# Altium schematic sheet MCTA_V2_070322.SchDoc(MCTA_V2_070322)
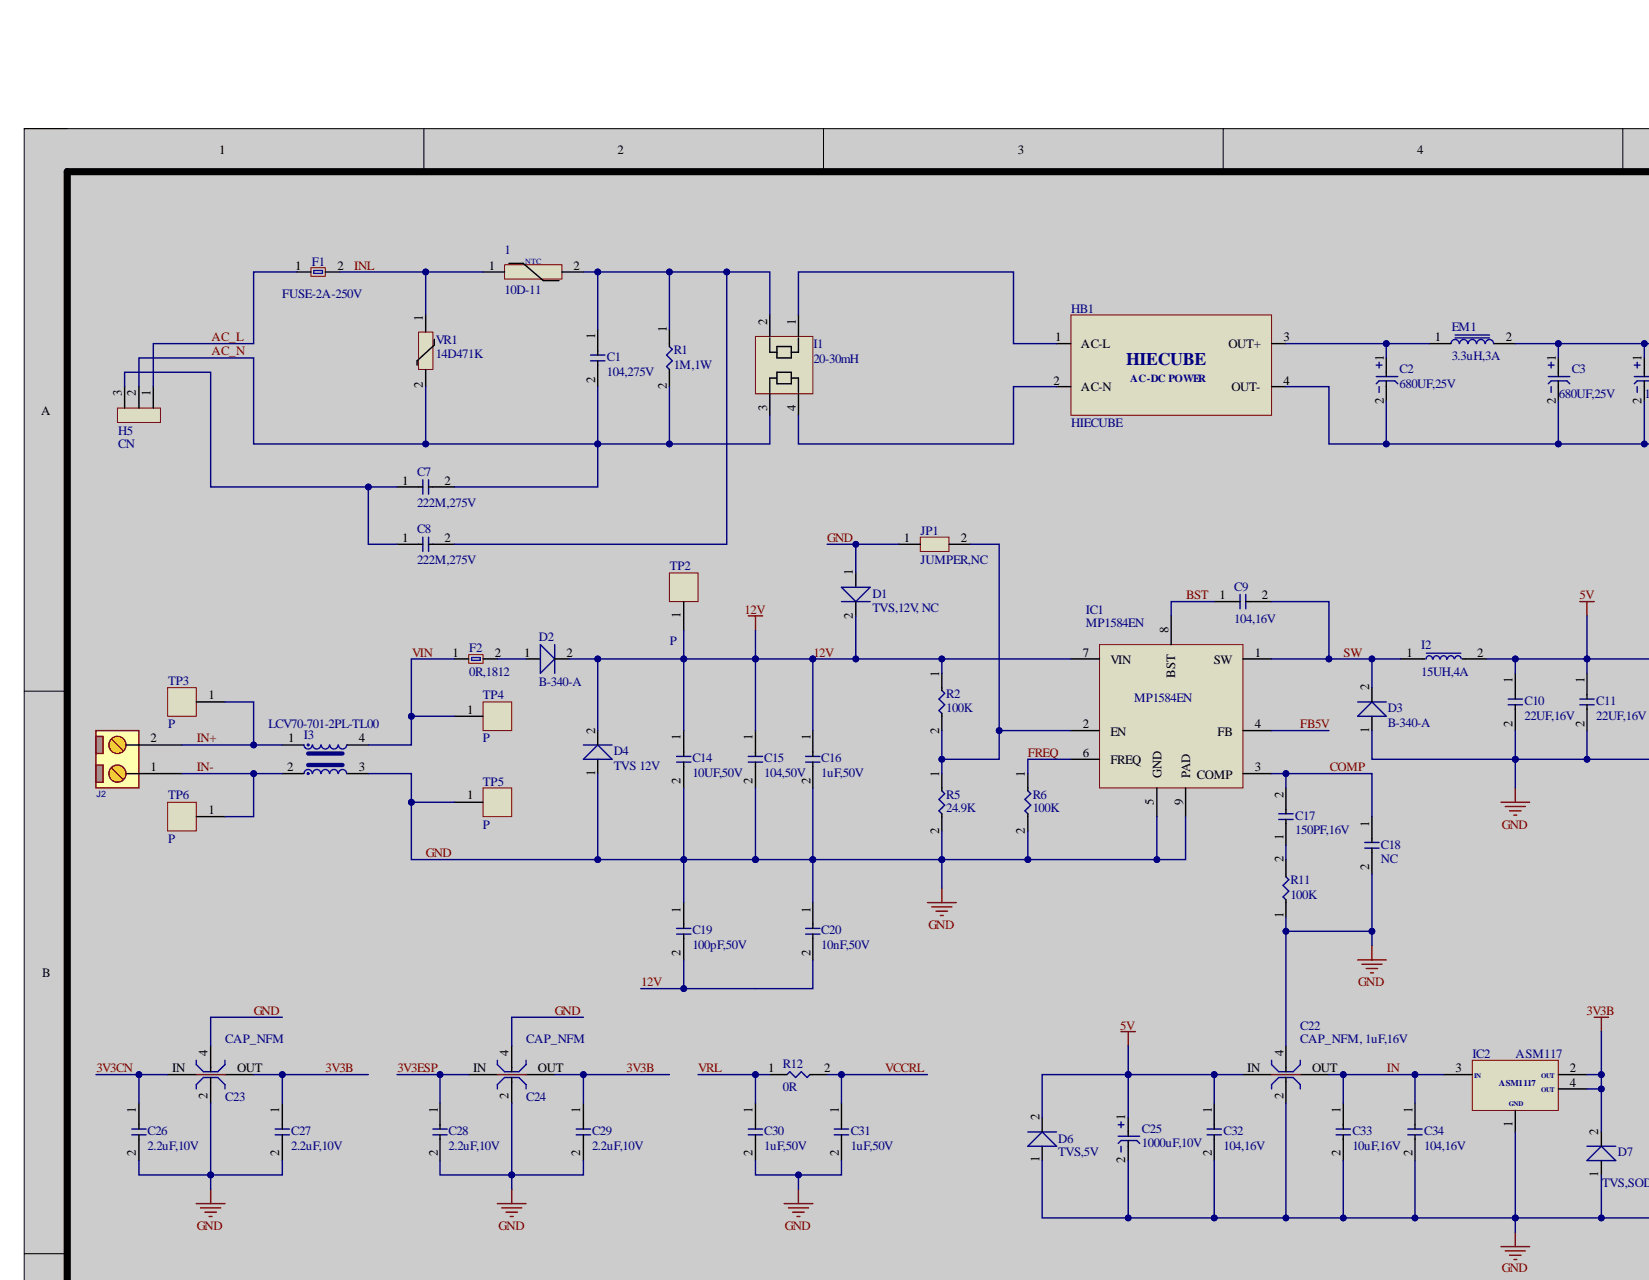
[diagram p0: MCTA_V2_070322.SchDoc, top left]
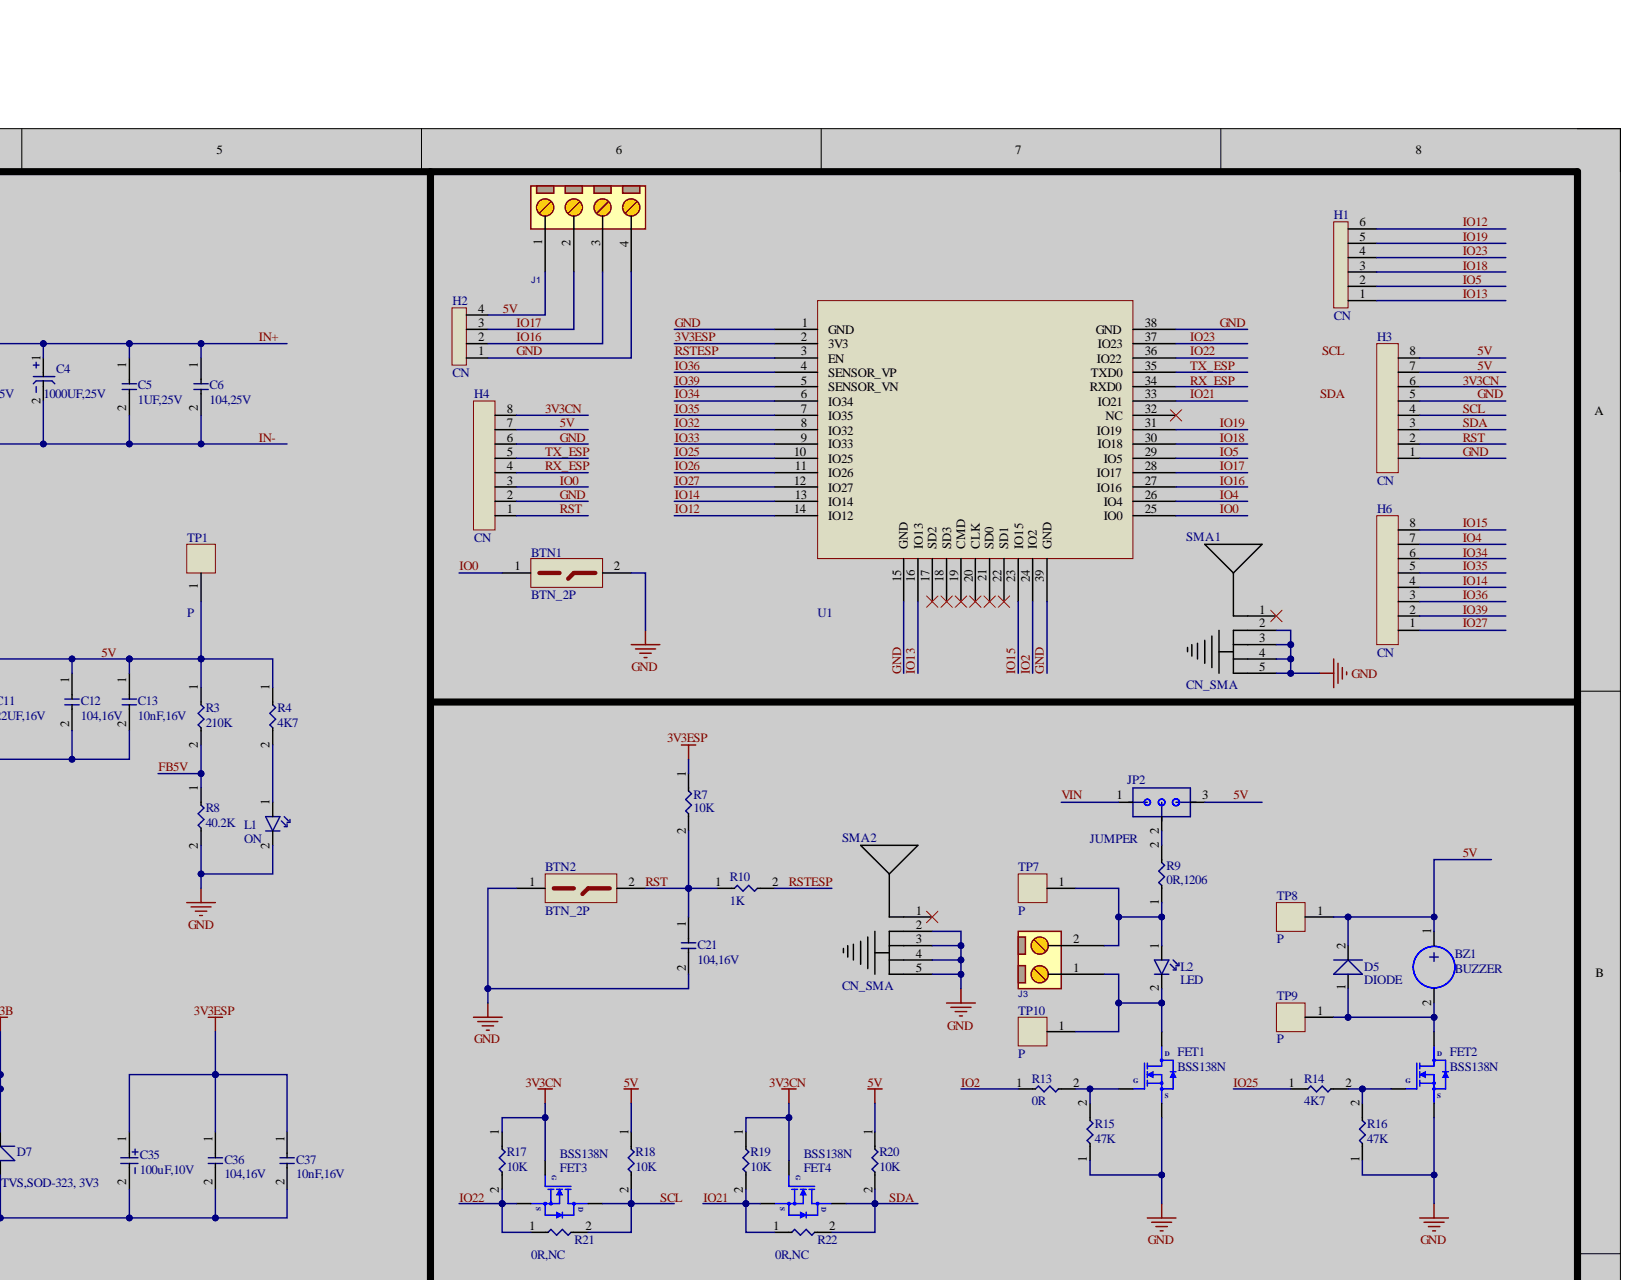
[diagram p1: MCTA_V2_070322.SchDoc, top right]
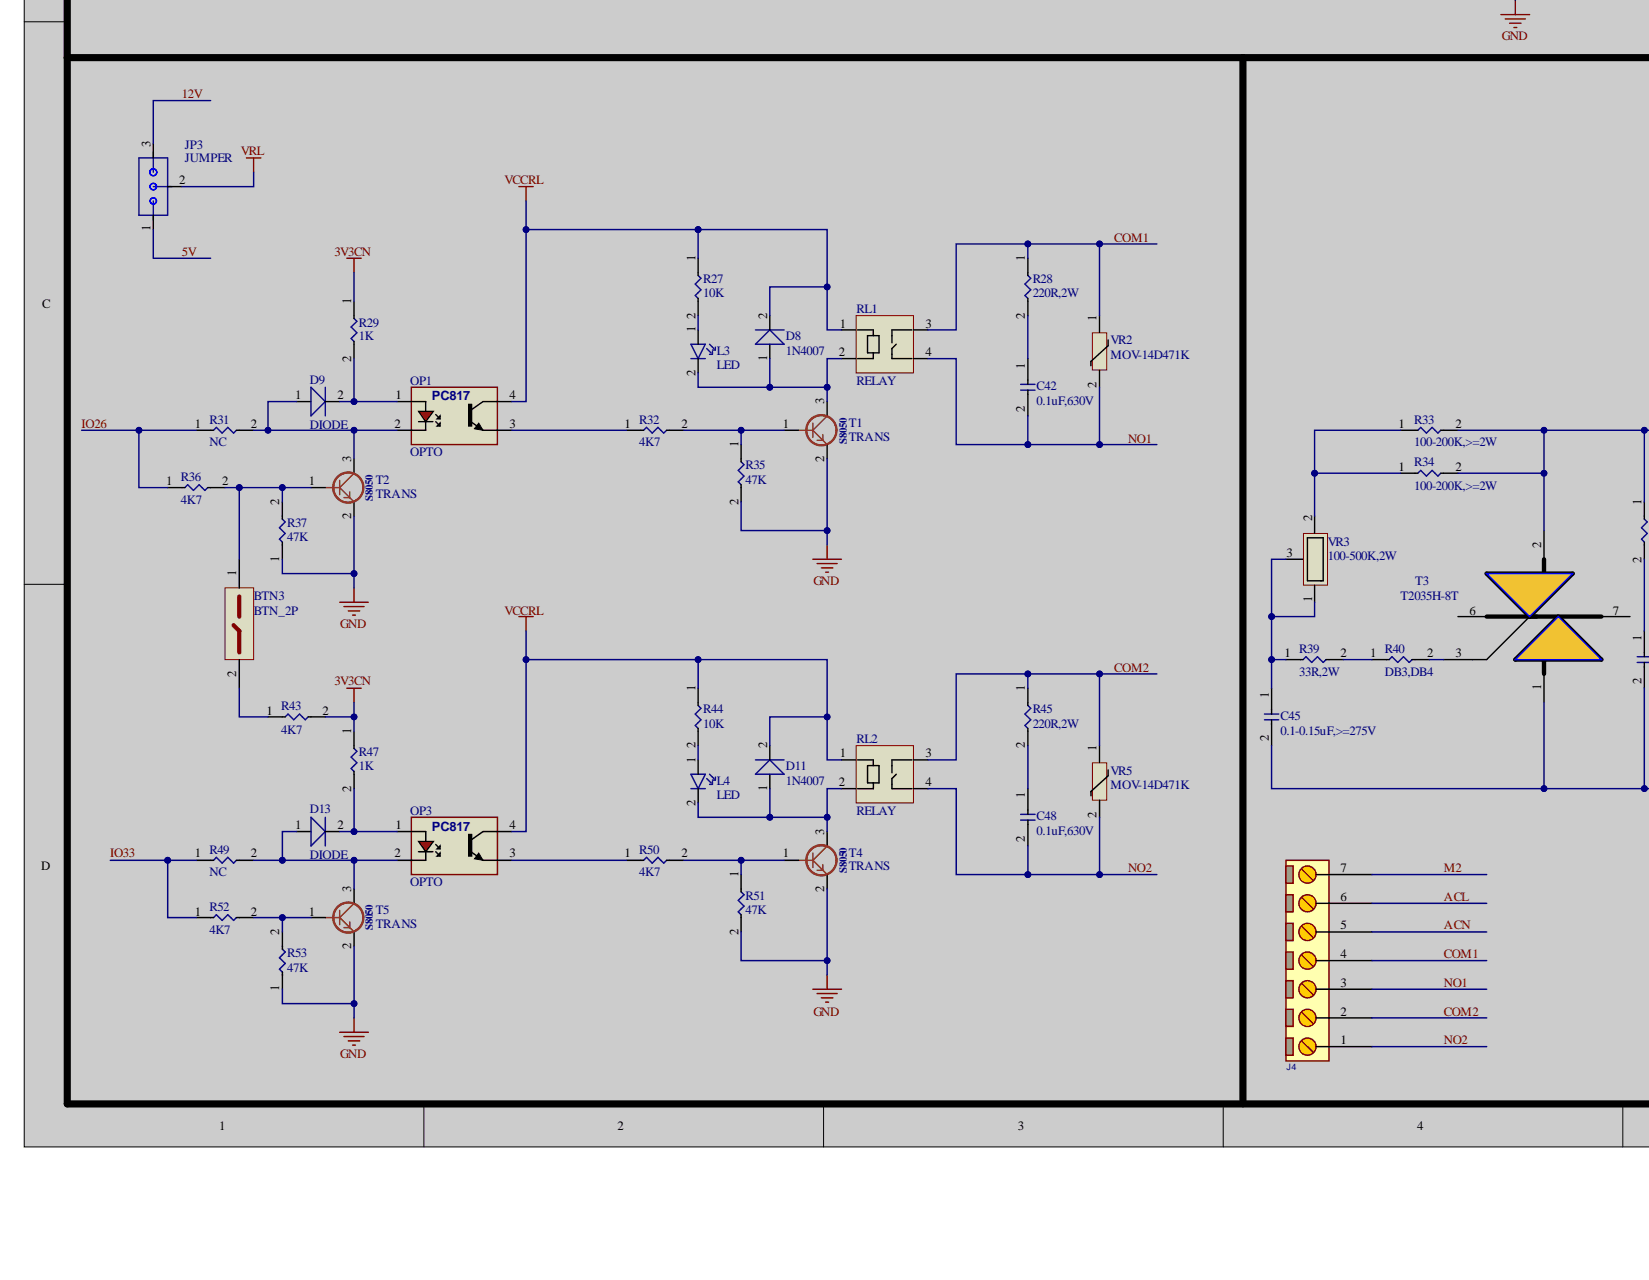
[diagram p2: MCTA_V2_070322.SchDoc, bottom left]
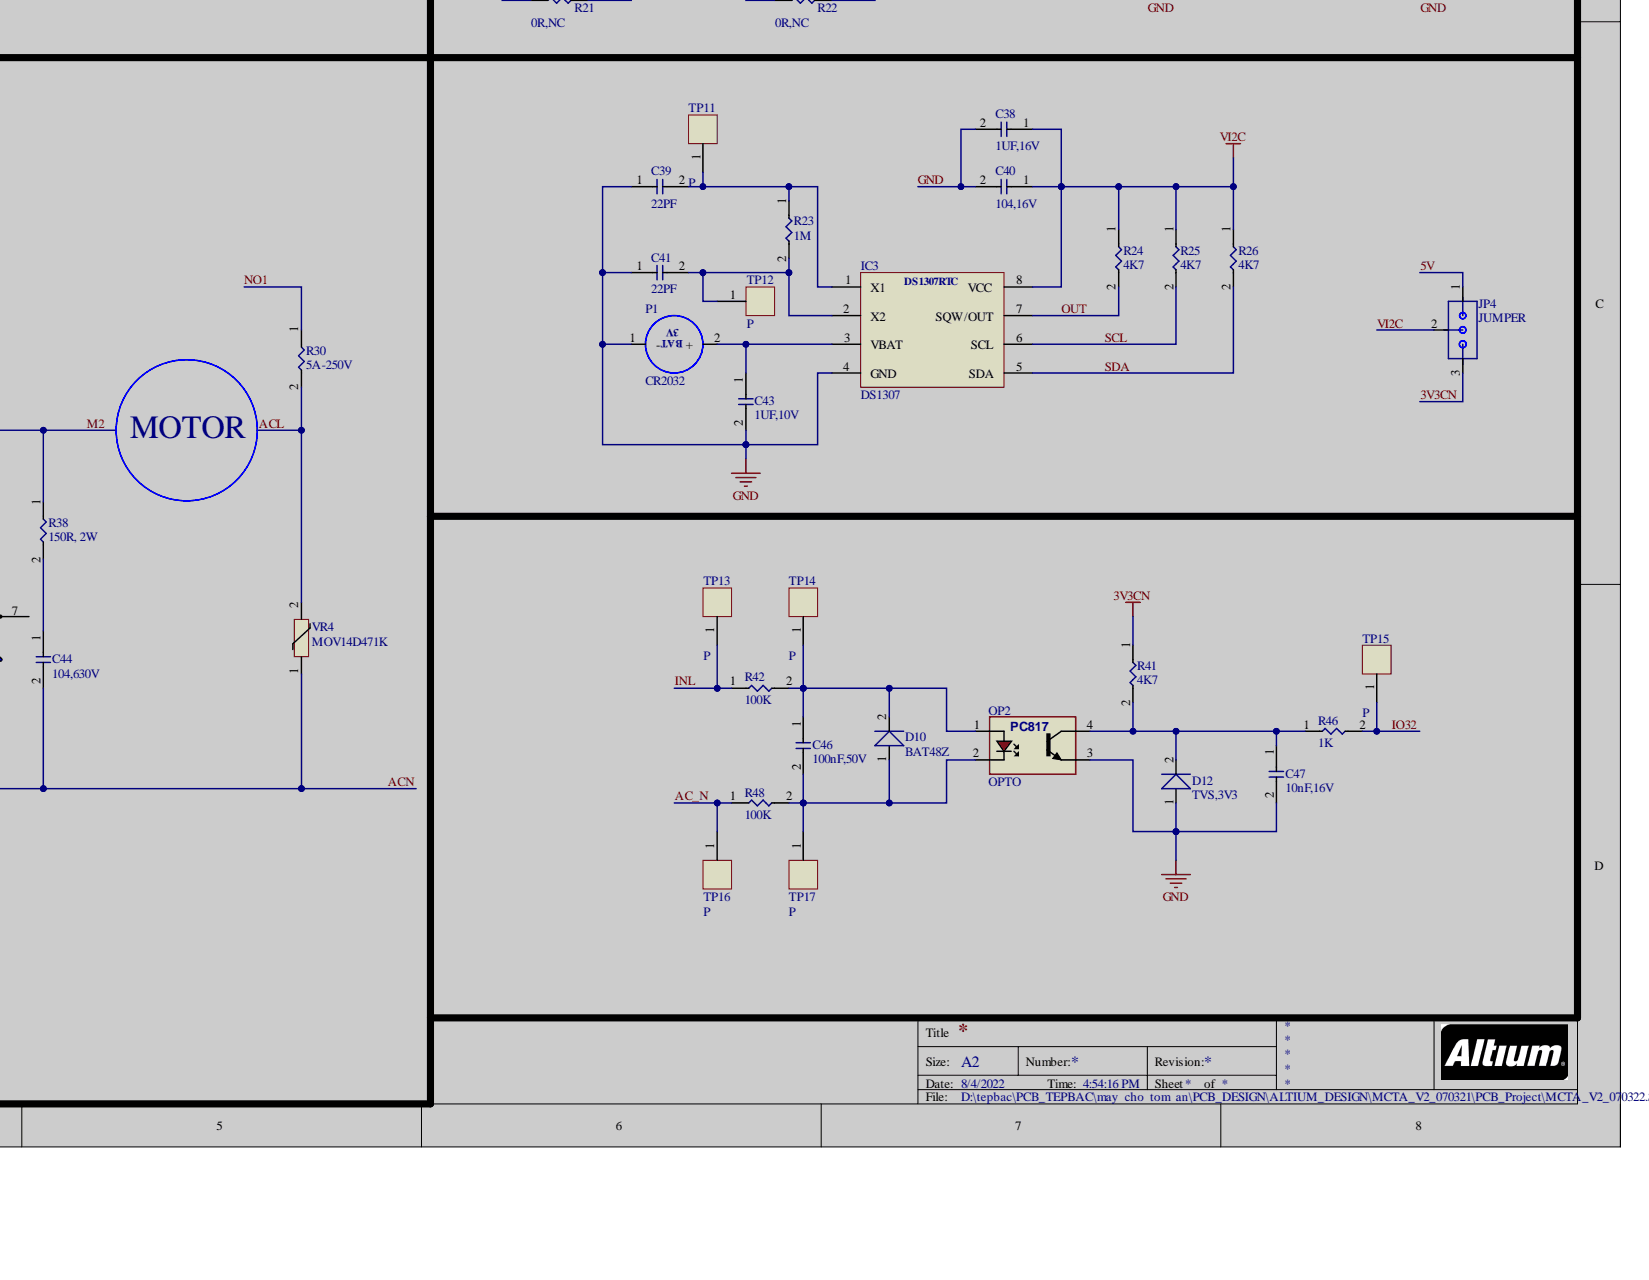
[diagram p3: MCTA_V2_070322.SchDoc, bottom right]

## Parts
1  10D-11  [NTC10D11]
BTN1  BTN_2P  [SMD2]
BTN2  BTN_2P  [SMD2]
BTN3  BTN_2P  [SMD2]
BZ1  BUZZER  [BUZZER 5V]
C1  104,275V  [CAP_GOLD_DIP]
C2  680UF,25V  [CAP_POL_DIP]
C3  680UF,25V  [CAP_POL_DIP]
C4  1000UF,25V  [CAP_POL_DIP]
C5  1UF,25V  [cap_smd_0603]
C6  104,25V  [cap_smd_0603]
C7  222M,275V  [CAP_CER_AC]
C8  222M,275V  [CAP_CER_AC]
C9  104,16V  [cap_smd_0603]
C10  22UF,16V  [CAP_SMD_1210]
C11  22UF,16V  [CAP_SMD_1210]
C12  104,16V  [cap_smd_0603]
C13  10nF,16V  [cap_smd_0603]
C14  10UF,50V  [CAP_SMD_1210]
C15  104,50V  [cap_smd_0603]
C16  1uF,50V  [cap_smd_0603]
C17  150PF,16V  [cap_smd_0603]
C18  NC  [cap_smd_0603]
C19  100pF,50V  [cap_smd_0603]
C20  10nF,50V  [cap_smd_0603]
C21  104,16V  [cap_smd_0603]
C22  CAP_NFM, 1uF,16V  [NFM21PC105B1A3D]
C23  CAP_NFM  [NFM21PC105B1A3D]
C24  CAP_NFM  [NFM21PC105B1A3D]
C25  1000uF,10V  [CAP_POL_35MM]
C26  2.2uF,10V  [cap_smd_0805]
C27  2.2uF,10V  [cap_smd_0805]
C28  2.2uF,10V  [cap_smd_0805]
C29  2.2uF,10V  [cap_smd_0805]
C30  1uF,50V  [cap_smd_0805]
C31  1uF,50V  [cap_smd_0805]
C32  104,16V  [cap_smd_0603]
C33  10uF,16V  [cap_smd_0805]
C34  104,16V  [cap_smd_0603]
C35  100uF,10V  [CAP_TAN_B]
C36  104,16V  [cap_smd_0603]
C37  10nF,16V  [cap_smd_0603]
C38  1UF,16V  [cap_smd_0805]
C39  22PF  [cap_smd_0603]
C40  104,16V  [cap_smd_0603]
C41  22PF  [cap_smd_0603]
C42  0.1uF,630V  [CAP_CBB]
C43  1UF,10V  [cap_smd_0603]
C44  104,630V  [CAP_CBB]
C45  0.1-0.15uF,>=275V  [CAP_CBB]
C46  100nF,50V  [CAP_CER_AC]
C47  10nF,16V  [cap_smd_0603]
C48  0.1uF,630V  [CAP_CBB]
D1  TVS,12V, NC  [DIODE_SMD_SOD_323]
D2  B-340-A  [diode_smd_DO_214AC]
D3  B-340-A  [diode_smd_DO_214AC]
D4  TVS 12V  [diode_smd_DO_214AC]
D5  DIODE  [DIODE_SMD_SOD_323]
D6  TVS,5V  [diode_smd_DO_214AC]
D7  TVS,SOD-323, 3V3  [DIODE_SMD_SOD_323]
D8  1N4007  [DIODE_SMD_SOD_123]
D9  DIODE  [DIODE_SMD_SOD_323]
D10  BAT48Z  [DIODE_SMD_SOD_323]
D11  1N4007  [DIODE_SMD_SOD_123]
D12  TVS,3V3  [DIODE_SMD_SOD_323]
D13  DIODE  [DIODE_SMD_SOD_323]
EM1  3.3uH,3A  [EMI_FILTER]
F1  FUSE-2A-250V  [FAC2A]
F2  0R,1812  [fuse_1812]
FET1  BSS138N  [BSS138_N]
FET2  BSS138N  [BSS138_N]
FET3  BSS138N  [BSS138_N]
FET4  BSS138N  [BSS138_N]
H1  CN  [6X01P_C_T]
H2  CN  [4X01P_T]
H3  CN  [8X01P_C_T]
H4  CN  [8X01P_T]
H5  CN  [KF762_3P]
H6  CN  [8X01P_C_T]
HB1  HIECUBE  [HIECUBE12VDC]
I1  20-30mH  [IN_FILTER]
I2  15UH,4A  [0630]
I3  LCV70-701-2PL-TL00  [LCV70-701-2PL-TL00]
IC1  MP1584EN  [MP1584EN-LF-Z]
IC2  ASM117  [ASM1117]
IC3  DS1307  [DS1307]
J1  CONN_TB4  [P_TBG_4]  (value not drawn)
J2  CONN_TB2  [P_TBG_2]  (value not drawn)
J3  CONN_TB2  [P_TBG_2]  (value not drawn)
J4  CONN_TB7  [BR762_7P]  (value not drawn)
JP1  JUMPER,NC  [jum_power]
JP2  JUMPER  [JUMP_3P]
JP3  JUMPER  [JUMP_3P]
JP4  JUMPER  [JUMP_3P]
L1  ON  [led_0603_blue]
L2  LED  [led_0603_blue]
L3  LED  [led_0603_blue]
L4  LED  [led_0603_blue]
OP1  OPTO  [PC817_SMD]
OP2  OPTO  [PC817_SMD]
OP3  OPTO  [PC817_SMD]
P1  CR2032  [CR1220]
R1  1M,1W  [R_DIP_1W]
R2  100K  [0603_R_L]
R3  210K  [0603_R_L]
R4  4K7  [0603_R_L]
R5  24.9K  [0603_R_L]
R6  100K  [0603_R_L]
R7  10K  [0603_R_L]
R8  40.2K  [0603_R_L]
R9  0R,1206  [1206_R_L]
R10  1K  [0603_R_L]
R11  100K  [0603_R_L]
R12  0R  [0805_R_L]
R13  0R  [0603_R_L]
R14  4K7  [0603_R_L]
R15  47K  [0603_R_L]
R16  47K  [0603_R_L]
R17  10K  [0603_R_L]
R18  10K  [0603_R_L]
R19  10K  [0603_R_L]
R20  10K  [0603_R_L]
R21  0R,NC  [0603_R_L]
R22  0R,NC  [0603_R_L]
R23  1M  [0603_R_L]
R24  4K7  [0603_R_L]
R25  4K7  [0603_R_L]
R26  4K7  [0603_R_L]
R27  10K  [0603_R_L]
R28  220R,2W  [R2W_B]
R29  1K  [0603_R_L]
R30  5A-250V  [R2W_B]
R31  NC  [0603_R_L]
R32  4K7  [0603_R_L]
R33  100-200K,>=2W  [R2W_B]
R34  100-200K,>=2W  [R2W_B]
R35  47K  [0603_R_L]
R36  4K7  [0603_R_L]
R37  47K  [0603_R_L]
R38  150R, 2W  [R2W_B]
R39  33R,2W  [R2W_B]
R40  DB3,DB4  [R2W_B]
R41  4K7  [0603_R_L]
R42  100K  [1206_R_L]
R43  4K7  [0603_R_L]
R44  10K  [0603_R_L]
R45  220R,2W  [R2W_B]
R46  1K  [0603_R_L]
R47  1K  [0603_R_L]
R48  100K  [1206_R_L]
R49  NC  [0603_R_L]
R50  4K7  [0603_R_L]
R51  47K  [0603_R_L]
R52  4K7  [0603_R_L]
R53  47K  [0603_R_L]
RL1  RELAY  [RELAY_SONGLE_30A]
RL2  RELAY  [RELAY_SONGLE_30A]
SMA1  CN_SMA  [SMA_0731000114]
SMA2  CN_SMA  [SMA_0731000114]
T1  TRANS  [S8050]
T2  TRANS  [S8050]
T3  T2035H-8T  [T2035H-8T]
T4  TRANS  [S8050]
T5  TRANS  [S8050]
TP1  P  [TP]
TP2  P  [TP]
TP3  P  [TP]
TP4  P  [TP]
TP5  P  [TP]
TP6  P  [TP]
TP7  P  [TP]
TP8  P  [TP]
TP9  P  [TP]
TP10  P  [TP]
TP11  P  [TP]
TP12  P  [TP]
TP13  P  [TP]
TP14  P  [TP]
TP15  P  [TP]
TP16  P  [TP]
TP17  P  [TP]
U1  ESP32-WROOM-32  [ESP32-WROOM-32D]  (value not drawn)
VR1  14D471K  [VARISTOR]
VR2  MOV-14D471K  [VARISTOR]
VR3  100-500K,2W  [RV24YN20S]
VR4  MOV14D471K  [VARISTOR]
VR5  MOV-14D471K  [VARISTOR]

## Nets
3V3B: C23.OUT C24.OUT C27.1 C29.1 D7.2 IC2.2(OUT) IC2.4(OUT)
3V3CN: C23.IN C26.1 FET3.1 FET4.1 H3.6 H4.8 JP4.3 R17.1 R19.1 R29.1 R41.1 R43.2 R47.1
3V3ESP: C24.IN C28.1 C35.1 C36.1 C37.1 R7.1 U1.2(3V3)
5V: BZ1.1 C10.1 C11.1 C12.1 C13.1 C22.IN C25.1 C32.1 D5.2 D6.2 H2.4 H3.7 H3.8 H4.7 I2.2 J1.1 JP2.3 JP3.1 JP4.1 R3.1 R4.1 R18.1 R20.1 TP1.1 TP8.1
12V: C14.1 C15.1 C16.1 C19.2 C20.2 D1.2 D2.2 D4.2 IC1.7(VIN) JP3.3 R2.1 TP2.1
AC_L: F1.1 H5.1
AC_N: C1.2 C7.2 H5.2 I1.3 R1.2 R48.1 TP16.1 VR1.2
ACL: J4.6 R30.2 VR4.2
ACN: C44.2 C45.2 J4.5 T3.1 VR4.1
BST: C9.1 IC1.8(BST)
COM1: J4.4 R28.1 RL1.3 VR2.1
COM2: J4.2 R45.1 RL2.3 VR5.1
COMP: C17.2 C18.1 IC1.3(COMP)
FB5V: IC1.4(FB) R3.2 R8.1
FREQ: IC1.6(FREQ) R6.1
GND: BTN1.2 BTN2.1 C10.2 C11.2 C12.2 C13.2 C14.2 C15.2 C16.2 C18.2 C19.1 C20.1 C21.2 C22.2 C22.4 C23.2 C23.4 C24.2 C24.4 C25.2 C26.2 C27.2 C28.2 C29.2 C30.2 C31.2 C32.2 C33.2 C34.2 C35.2 C36.2 C37.2 C38.2 C39.1 C40.2 C41.1 C43.2 C47.2 D1.1 D3.1 D4.1 D6.1 D7.1 D12.1 FET1.2 FET2.2 H2.1 H3.1 H3.5 H4.2 H4.6 I3.3 IC1.5(GND) IC1.9(PAD) IC2.1 IC3.4(GND) J1.4 JP1.1 L1.2 OP2.3 P1.1 R5.2 R6.2 R8.2 R11.1 R15.1 R16.1 R35.2 R37.1 R51.2 R53.1 SMA1.2 SMA1.3 SMA1.4 SMA1.5 SMA2.2 SMA2.3 SMA2.4 SMA2.5 T1.2 T2.2 T4.2 T5.2 TP5.1 U1.1(GND) U1.15(GND) U1.38(GND) U1.39(GND)
IN: C22.OUT C33.1 C34.1 IC2.3(IN)
IN+: C3.1 C4.1 C5.1 C6.1 EM1.2 I3.1 J2.2 TP3.1
IN-: C2.2 C3.2 C4.2 C5.2 C6.2 HB1.4(OUT-) I3.2 J2.1 TP6.1
INL: 1.1 F1.2 R42.1 TP13.1 VR1.1
IO0: BTN1.1 H4.3 U1.25(IO0)
IO2: R13.1 U1.24(IO2)
IO4: H6.7 U1.26(IO4)
IO5: H1.2 U1.29(IO5)
IO12: H1.6 U1.14(IO12)
IO13: H1.1 U1.16(IO13)
IO14: H6.4 U1.13(IO14)
IO15: H6.8 U1.23(IO15)
IO16: H2.2 J1.3 U1.27(IO16)
IO17: H2.3 J1.2 U1.28(IO17)
IO18: H1.3 U1.30(IO18)
IO19: H1.5 U1.31(IO19)
IO21: FET4.2 R19.2 R22.1 U1.33(IO21)
IO22: FET3.2 R17.2 R21.1 U1.36(IO22)
IO23: H1.4 U1.37(IO23)
IO25: R14.1 U1.10(IO25)
IO26: R31.1 R36.1 U1.11(IO26)
IO27: H6.1 U1.12(IO27)
IO32: R46.2 TP15.1 U1.8(IO32)
IO33: R49.1 R52.1 U1.9(IO33)
IO34: H6.6 U1.6(IO34)
IO35: H6.5 U1.7(IO35)
IO36: H6.3 U1.4(SENSOR_VP)
IO39: H6.2 U1.5(SENSOR_VN)
M2: J4.7 R33.2 R34.2 R38.1 T3.2
NO1: C42.2 J4.3 R30.1 RL1.4 VR2.2
NO2: C48.2 J4.1 RL2.4 VR5.2
OUT: IC3.7(SQW/OUT) R24.2
RST: BTN2.2 C21.1 H3.2 H4.1 R7.2 R10.1
RSTESP: R10.2 U1.3(EN)
RX_ESP: H4.4 U1.34(RXD0)
SCL: FET3.3 H3.4 IC3.6(SCL) R18.2 R21.2 R25.2
SDA: FET4.3 H3.3 IC3.5(SDA) R20.2 R22.2 R26.2
SW: C9.2 D3.2 I2.1 IC1.1(SW)
TX_ESP: H4.5 U1.35(TXD0)
VCCRL: C31.1 D8.2 D11.2 OP1.4 OP3.4 R12.2 R27.1 R44.1 RL1.1 RL2.1
VI2C: C38.1 C40.1 IC3.8(VCC) JP4.2 R24.1 R25.1 R26.1
VIN: F2.1 I3.4 JP2.1 TP4.1
VRL: C30.1 JP3.2 R12.1
Net1_2: 1.2 C1.1 C8.2 I1.2 R1.1
NetBTN3_1: BTN3.1 R36.2 R37.2 T2.1
NetBTN3_2: BTN3.2 R43.1
NetBZ1_2: BZ1.2 D5.1 FET2.3 TP9.1
NetC2_1: C2.1 EM1.1 HB1.3(OUT+)
NetC7_1: C7.1 C8.1 H5.3
NetC17_1: C17.1 R11.2
NetC39_2: C39.2 IC3.1(X1) R23.1 TP11.1
NetC41_2: C41.2 IC3.2(X2) R23.2 TP12.1
NetC42_1: C42.1 R28.2
NetC43_1: C43.1 IC3.3(VBAT) P1.2
NetC44_1: C44.1 R38.2
NetC45_1: C45.1 R39.1 VR3.1 VR3.3
NetC46_1: C46.1 D10.2 OP2.1 R42.2 TP14.1
NetC46_2: C46.2 D10.1 OP2.2 R48.2 TP17.1
NetC47_1: C47.1 D12.2 OP2.4 R41.2 R46.1
NetC48_1: C48.1 R45.2
NetD2_1: D2.1 F2.2
NetD8_1: D8.1 L3.2 RL1.2 T1.3
NetD9_1: D9.1 OP1.2 R31.2 T2.3
NetD9_2: D9.2 OP1.1 R29.2
NetD11_1: D11.1 L4.2 RL2.2 T4.3
NetD13_1: D13.1 OP3.2 R49.2 T5.3
NetD13_2: D13.2 OP3.1 R47.2
NetFET1_1: FET1.1 R13.2 R15.2
NetFET1_3: FET1.3 J3.1 L2.2 TP10.1
NetFET2_1: FET2.1 R14.2 R16.2
NetHB1_1: HB1.1(AC-L) I1.1
NetHB1_2: HB1.2(AC-N) I1.4
NetIC1_2: IC1.2(EN) JP1.2 R2.2 R5.1
NetJ3_2: J3.2 L2.1 R9.1 TP7.1
NetJP2_2: JP2.2 R9.2
NetL1_1: L1.1 R4.2
NetL3_1: L3.1 R27.2
NetL4_1: L4.1 R44.2
NetOP1_3: OP1.3 R32.1
NetOP3_3: OP3.3 R50.1
NetR32_2: R32.2 R35.1 T1.1
NetR33_1: R33.1 R34.1 VR3.2
NetR39_2: R39.2 R40.1
NetR40_2: R40.2 T3.3
NetR50_2: R50.2 R51.1 T4.1
NetR52_2: R52.2 R53.2 T5.1

Not connected: SMA1 1; SMA2 1; T3 6 7; U1 17(SD2) 18(SD3) 19(CMD) 20(CLK) 21(SD0) 22(SD1) 32(NC)

## Library data of the parts
1 = NTC: Component Kind: Standard; Library Name: COMPONENT.IntLib
BZ1 = BUZZER 5V: Component Kind: Standard; Library Name: COMPONENT.IntLib
C1 C5-C21 C26-C34 C36-C48 = CAPACITOR: Component Kind: Standard; Library Name: COMPONENT.IntLib
C2-C4 C25 = CAP_ALU: Component Kind: Standard; Library Name: COMPONENT.IntLib
C22 = CAP_NFM: Component Kind: Standard; Library Name: COMPONENT.IntLib
C35 = CAP_TAN: Component Kind: Standard; Library Name: COMPONENT.IntLib
D1-D4 D6-D8 D10-D12 = DIODE: Component Kind: Standard; Library Name: COMPONENT.IntLib
EM1 = EMI_FILTER: Component Kind: Standard; Library Name: COMPONENT.IntLib
F1 F2 = FUSE: Component Kind: Standard; Library Name: COMPONENT.IntLib
FET1-FET4 = BSS138_TYPE_N: Component Kind: Standard; Library Name: COMPONENT.IntLib
H1 = 6x01p: Component Kind: Standard; Library Name: COMPONENT.IntLib
H2 = 4x01p: Component Kind: Standard; Library Name: COMPONENT.IntLib
H3 H4 H6 = 8x01p: Component Kind: Standard; Library Name: COMPONENT.IntLib
H5 = 3x01p: Component Kind: Standard; Library Name: COMPONENT.IntLib
HB1 = HB_12VDC: Component Kind: Standard; Library Name: AC_DC_DC_DC.IntLib
I1 = IN_FILTER: Component Kind: Standard; Library Name: COMPONENT.IntLib
I2 = INDUCTOR: INDUCTOR ,CD47,100,150UH,SIZE 12X12X7; Component Kind: Standard; Library Name: COMPONENT.IntLib
I3 = FILTER_CM_CHOKES: Component Kind: Standard; Library Name: COMPONENT.IntLib
IC1 = MP1584EN-LF-Z: Component Kind: Standard; Library Name: POWER_SUPPLY_ICs.IntLib
IC2 = ASM1117: Component Kind: Standard; Library Name: POWER_SUPPLY_ICs.IntLib
J1 = TB4 GREEN: CONN, TERMINAL BLOCK, 5.08mm pitch; Component Kind: Standard; Library Name: TERMINAL.IntLib; VALUE: CONN_TB4
J2 J3 = TB2 GREEN: CONN, TERMINAL BLOCK, 5.08mm pitch; Component Kind: Standard; Library Name: TERMINAL.IntLib; VALUE: CONN_TB2
J4 = TB7 GREEN: CONN, TERMINAL BLOCK, 5.08mm pitch; Component Kind: Standard; Library Name: TERMINAL.IntLib; VALUE: CONN_TB7
JP1 = JP_POWER: Component Kind: Standard; Library Name: COMPONENT.IntLib
JP2-JP4 = JP_3P: Component Kind: Standard; Library Name: COMPONENT.IntLib
L1 = LED: Component Kind: Standard; Library Name: COMPONENT.IntLib
OP1-OP3 = PC817: Component Kind: Standard; Library Name: COMPONENT.IntLib
R1 R9 R12-R16 R27-R53 = RESISTOR: TYPE DIP & SMD; Component Kind: Standard; Library Name: COMPONENT.IntLib
R2-R8 R10 R11 R17-R26 = RESISTOR: Component Kind: Standard; Description: resistor; Library Name: COMPONENT.IntLib
RL1 RL2 = OMRON12V: Component Kind: Standard; Library Name: COMPONENT.IntLib
T1 T2 T4 T5 = S8050: Component Kind: Standard; Library Name: COMPONENT.IntLib
T3 = T2035H-8T: TRIAC- TO-220AB; Component Kind: Standard; Library Name: COMPONENT.IntLib
TP1-TP17 = TP: Component Kind: Standard; Library Name: COMPONENT.IntLib
U1 = ESP32-WROOM-32: ESP32; Manufacturer: Espressif Inc.; Reviewer: Fenrrich; Component Kind: Standard; ComponentLink1Description: Datasheet; ComponentLink1URL: https://www.espressif.com/sites/default/files/documentation/esp32-wroom-32_datasheet_en.pdf; Library Name: WIRELESS_MODULE.IntLib; Part Number: ESP32-WROOM-32; Data Reviewer: 03-May-2019; Publisher: Cynetik Group
VR1 VR2 VR4 VR5 = MOV: Component Kind: Standard; Library Name: COMPONENT.IntLib
VR3 = VRP: RR; Component Kind: Standard; ComponentLink1Description: VR-3362P; ComponentLink1URL: https://item.taobao.com/item.htm?spm=a312a.7700824.w4002-21223910190.12.1f6d40d3BuEv0x&id=532123981478; Library Name: COMPONENT.IntLib
BTN1-BTN3 = BTN_2P: Component Kind: Standard; Library Name: COMPONENT.IntLib
C23 C24 = CAP_NFM: Component Kind: Standard; Library Name: COMPONENT.IntLib
D5 D9 D13 = DIODE: Component Kind: Standard; Library Name: COMPONENT.IntLib
IC3 = DS1307: Component Kind: Standard; Library Name: MEMORY_RTC_CLOCK.IntLib
L2-L4 = LED: Component Kind: Standard; Library Name: COMPONENT.IntLib
P1 = CR2032: Component Kind: Standard; Library Name: COMPONENT.IntLib
SMA1 SMA2 = CN_SMA: Component Kind: Standard; Library Name: COMPONENT.IntLib
